# Altium schematic sheet ECU_Power 2.SchDoc
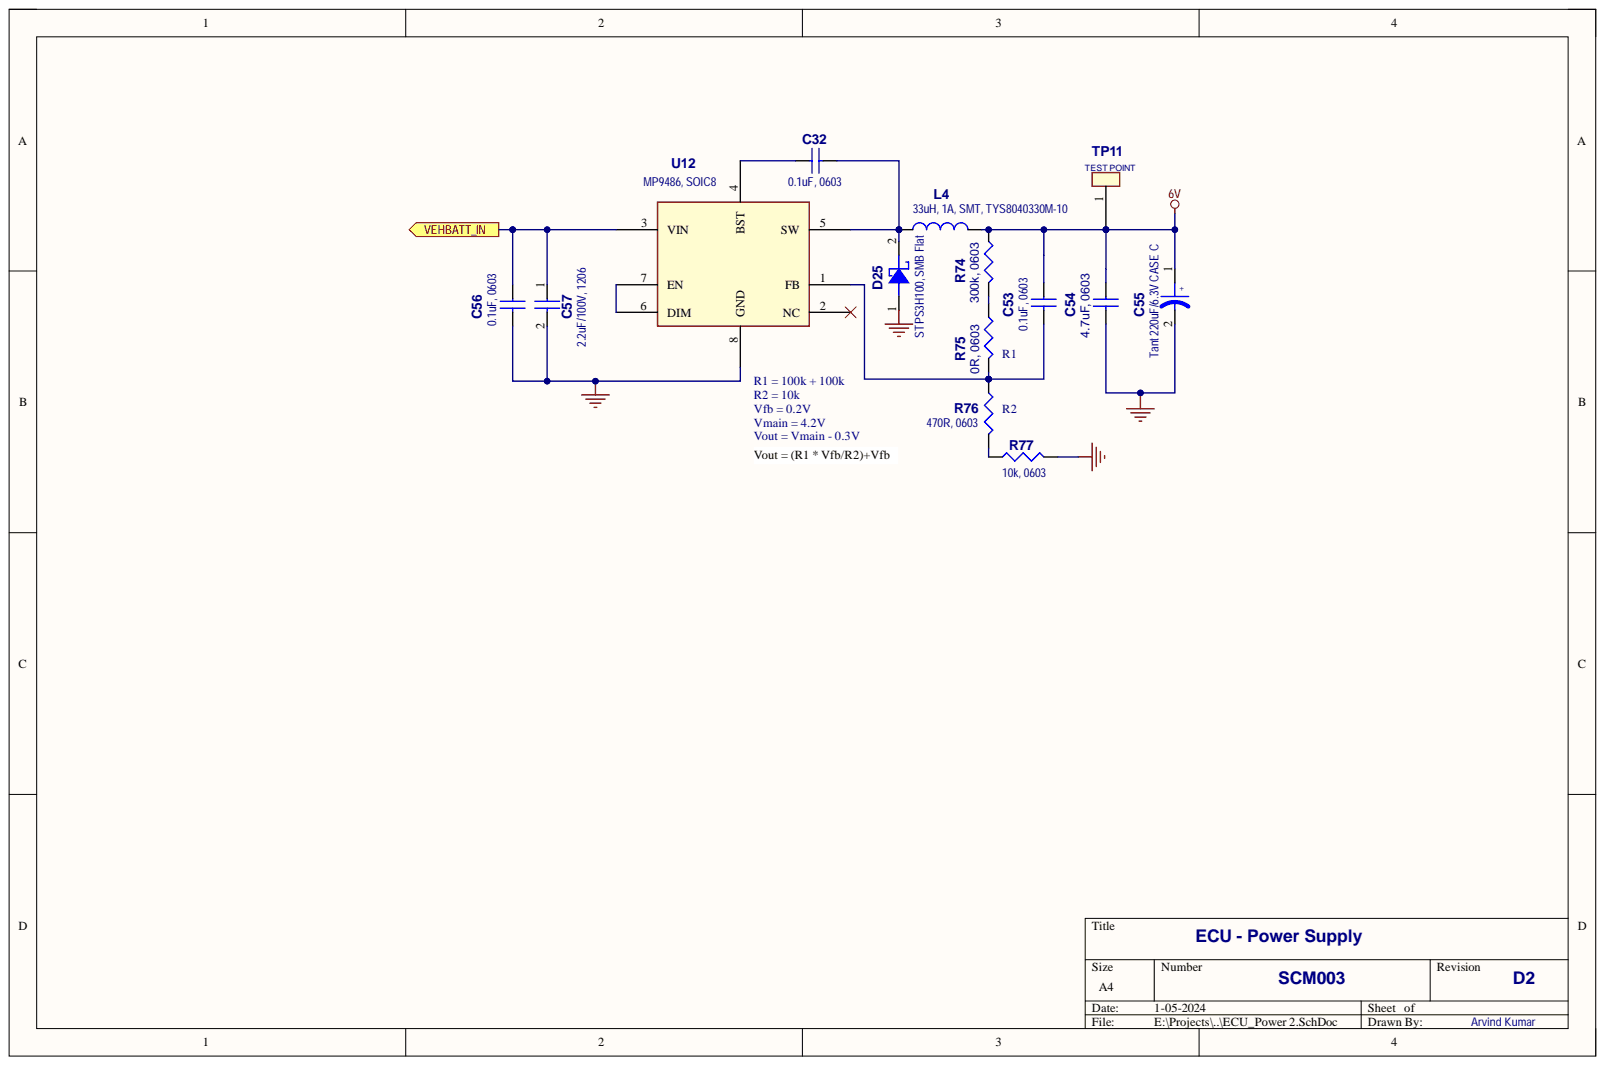
[diagram p0: ECU_Power 2.SchDoc]

## Parts
C32  0.1uF, 0603  [C0603]
C53  0.1uF, 0603  [C0603]
C54  4.7uF, 0603  [C0603]
C55  Tant 220uF/6.3V CASE C  [C19............CAP_TANT_2412-C_case]
C56  0.1uF, 0603  [C0603]
C57  2.2uF/100V, 1206  [C20, C21..........C1206]
D25  STPS3H100, SMB Flat  [STPS3H100]
L4  33uH, 1A, SMT, TYS8040330M-10  [330UH]
R74  300k, 0603  [R0603]
R75  0R, 0603  [R0603]
R76  470R, 0603  [R0603]
R77  10k, 0603  [R0603]
TP11  TEST POINT  [Test Point]
U12  MP9486, SOIC8  [MP9486]

## Ports
VEHBATT_IN

## Nets
6V: C53.1 C54.1 C55.1 L4.2 R74.1 TP11.1
GND_POWER: C54.2 C55.2 C56.2 C57.2 D25.1 R77.2 U12.8(GND)
VEHBATT_IN: C56.1 C57.1 U12.3(VIN) ; port VEHBATT_IN
NetC32_1: C32.1 U12.4(BST)
NetC32_2: C32.2 D25.2 L4.1 U12.5(SW)
NetC53_2: C53.2 R75.2 R76.1 U12.1(FB)
NetR74_2: R74.2 R75.1
NetR76_2: R76.2 R77.1
NetU12_6: U12.6(DIM) U12.7(EN)

Not connected: U12 2(NC)

## Library data of the parts
C32 C53 C54 C56 C57 = CAP NP_Dup2: Component Kind: Standard
C55 = CAP ELEC: Component Kind: Standard
D25 = DIODE SCHOTTKY: Component Kind: Standard
L4 = INDUCTOR: Component Kind: Standard
R74-R77 = R_Dup1: Component Kind: Standard
U12 = MP9486: Component Kind: Standard
TP11 = TEST POINT: Component Kind: Standard
